# Altium schematic sheet 3.WIZ750SR-110_OPTIONAL.SchDoc
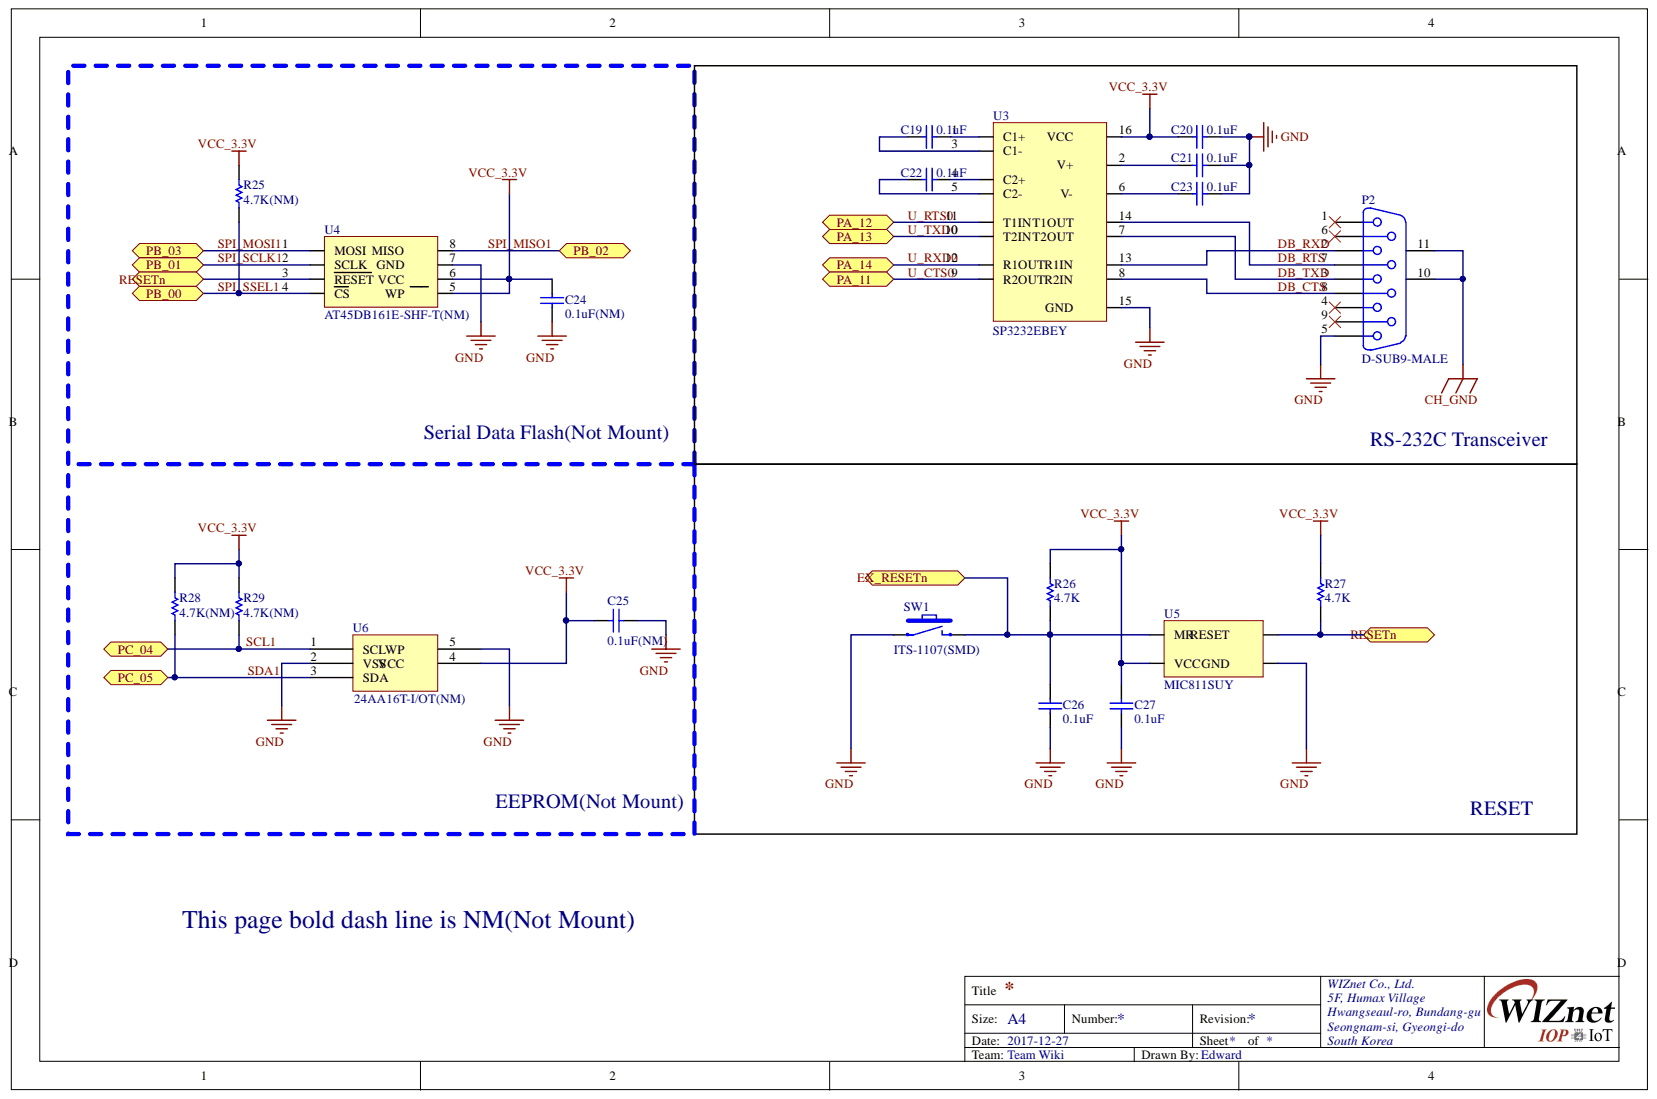
[diagram p0: 3.WIZ750SR-110_OPTIONAL.SchDoc]

## Parts
C19  0.1uF  [CAP-0603]
C20  0.1uF  [CAP-0603]
C21  0.1uF  [CAP-0603]
C22  0.1uF  [CAP-0603]
C23  0.1uF  [CAP-0603]
C24  0.1uF(NM)  [CAP-0603]
C25  0.1uF(NM)  [CAP-0603]
C26  0.1uF  [CAP-0603]
C27  0.1uF  [CAP-0603]
P2  D-SUB9-MALE  [D-SUB9-MALE]
R25  4.7K(NM)  [RES-0603]
R26  4.7K  [RES-0603]
R27  4.7K  [RES-0603]
R28  4.7K(NM)  [RES-0603]
R29  4.7K(NM)  [RES-0603]
SW1  ITS-1107(SMD)  [IT-1107(SMD)]
U3  SP3232EBEY  [TSSOP16(0.65/6.4)]
U4  AT45DB161E-SHF-T(NM)  [SO-08-EIAJ]
U5  MIC811SUY  [SOT-143]
U6  24AA16T-I/OT(NM)  [SOT-23-5L]

## Ports
EX_RESETN, PA_11, PA_12, PA_13, PA_14, PB_00, PB_01, PB_02, PB_03, PC_04, PC_05, RESETN

## Nets
CH_GND: P2.10 P2.11
GND: C20.1 C21.1 C23.1 C24.2 C25.1 C26.1 C27.1 P2.5 SW1.1 U3.15 U4.7 U5.1 U6.2(VSS) U6.5
VCC_3.3V: C20.2 C24.1 C25.2 C27.2 R25.2 R26.2 R27.2 R28.2 R29.2 U3.16 U4.5 U4.6 U5.4 U6.4
DB_CTS: P2.8 U3.8
DB_RTS: P2.7 U3.14
DB_RXD: P2.2 U3.13
DB_TXD: P2.3 U3.7
SCL1: R29.1 U6.1(SCL) ; port PC_04
SDA1: R28.1 U6.3(SDA) ; port PC_05
SPI_MISO1: U4.8 ; port PB_02
SPI_MOSI1: U4.1(MOSI) ; port PB_03
SPI_SCLK1: U4.2(SCLK) ; port PB_01
SPI_SSEL1: R25.1 U4.4(CS) ; port PB_00
U_CTS0: U3.9 ; port PA_11
U_RTS0: U3.11 ; port PA_12
U_RXD0: U3.12 ; port PA_14
U_TXD0: U3.10 ; port PA_13
NetC19_1: C19.1 U3.1(C1+)
NetC19_2: C19.2 U3.3(C1-)
NetC21_2: C21.2 U3.2
NetC22_1: C22.1 U3.4(C2+)
NetC22_2: C22.2 U3.5(C2-)
NetC23_2: C23.2 U3.6
NetC26_2: C26.2 R26.1 SW1.2 U5.3(MR) ; port EX_RESETN
NetR27_1: R27.1 U4.3 U5.2 ; port RESETN

Not connected: P2 1 4 6 9

## Library data of the parts
C19-C27 = CAP-0603: Ceramic CAP 0603(1608); Component Kind: Standard; Library Name: Scott_lib.IntLib
P2 = D-SUB9-MALE: D-SUB-9, MALE,  Right Angle; Gender: Receptacle; PackageDocument: O; Positions: 9; Component Kind: Standard; DatasheetDocument: 1-Sep-1999; LatestRevisionDate: 17-Jul-2002; LatestRevisionNote: Re-released for DXP Platform.; Orientation: Right Angle; PackageReference: 788750; Published: 15-Jun-2001; Library Name: Scott_lib.IntLib; Publisher: Altium Limited
R25-R29 = RES-0603: Component Kind: Standard; Description: RES-0603; Library Name: Scott_lib.IntLib
SW1 = ITS-1107(SMD): TACT SW, SMD; Component Kind: Standard; Library Name: Edward.IntLib
U3 = SP3232EBEY: True +3.0V to +5.5V RS-232 Transceivers TSSOP16; Component Kind: Standard; Library Name: Scott_lib.IntLib
U4 = AT45DB161E-SHF-T: 16-Mbit DataFlash, 2.3V or 2.5V Minimum SPI Serial Flash Memory; Component Kind: Standard; Library Name: Edward.IntLib
U5 = MIC811SUY: Microprocessor Reset Circuits; Component Kind: Standard; Library Name: Scott_lib.IntLib
U6 = 24AAxxT-i-OT: SOT-23; Component Kind: Standard; Library Name: 24AAxxT-OT.SchLib
